# Altium schematic sheet 0_Overview.SchDoc
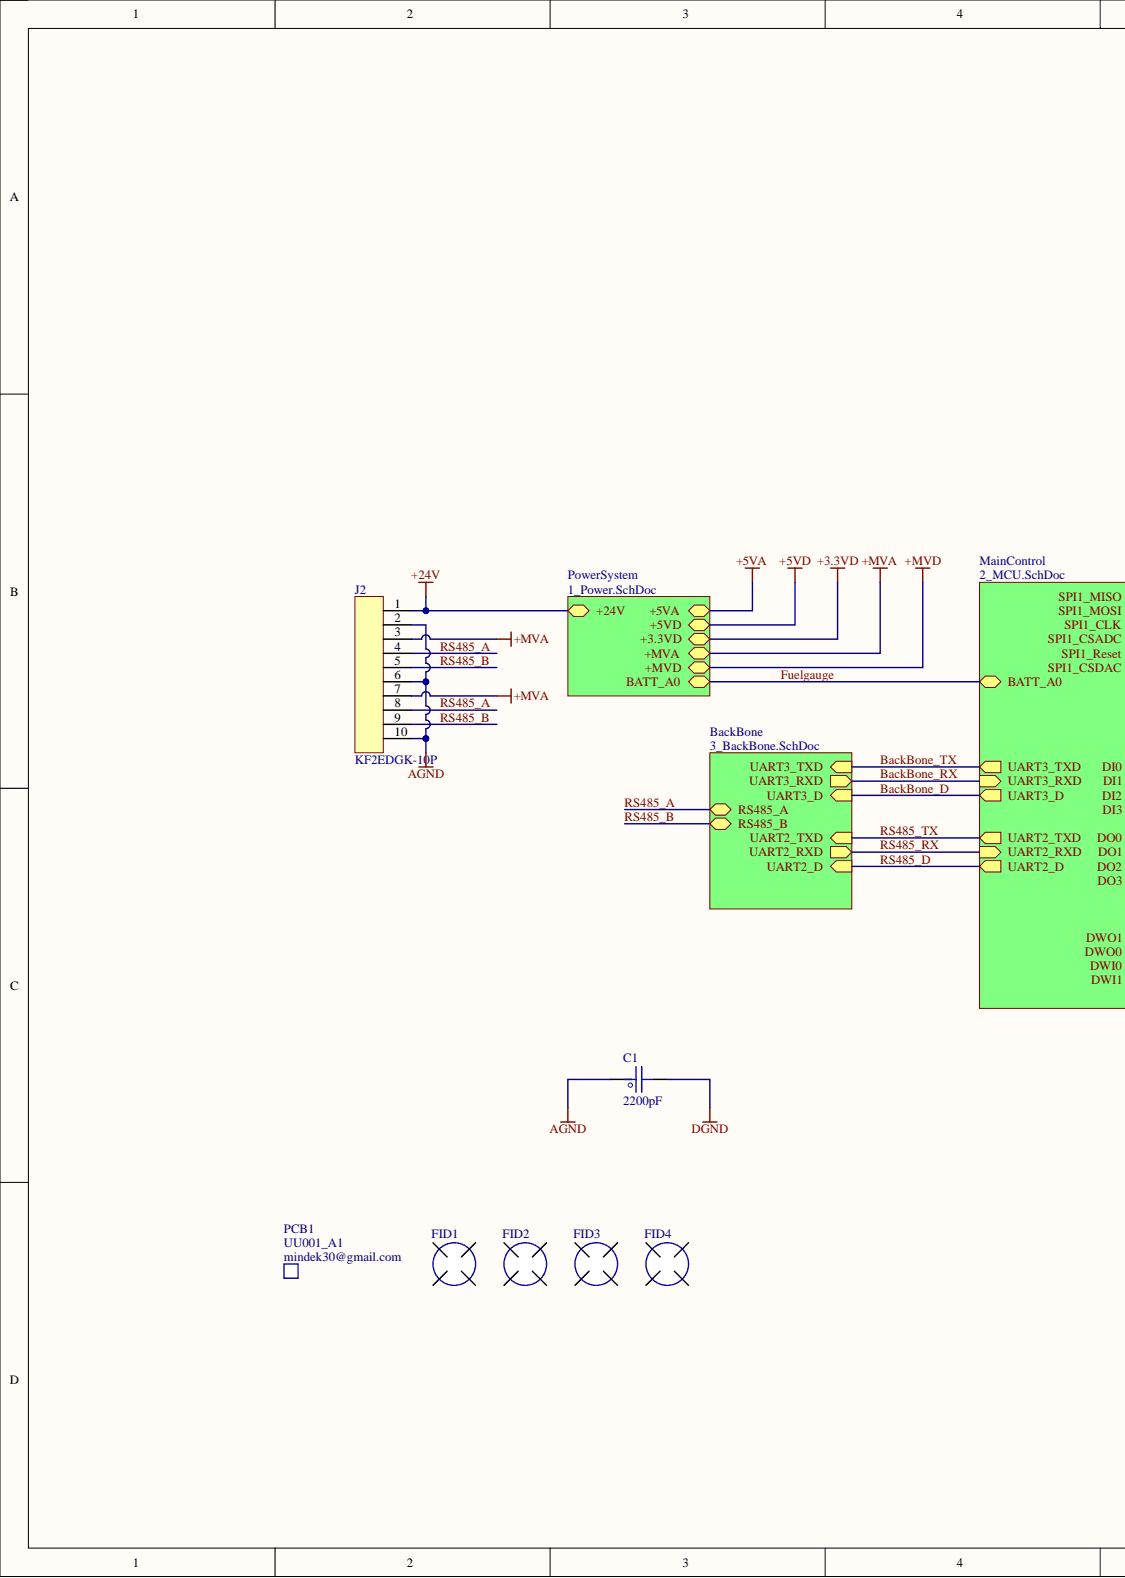
[diagram p0: 0_Overview.SchDoc, left]
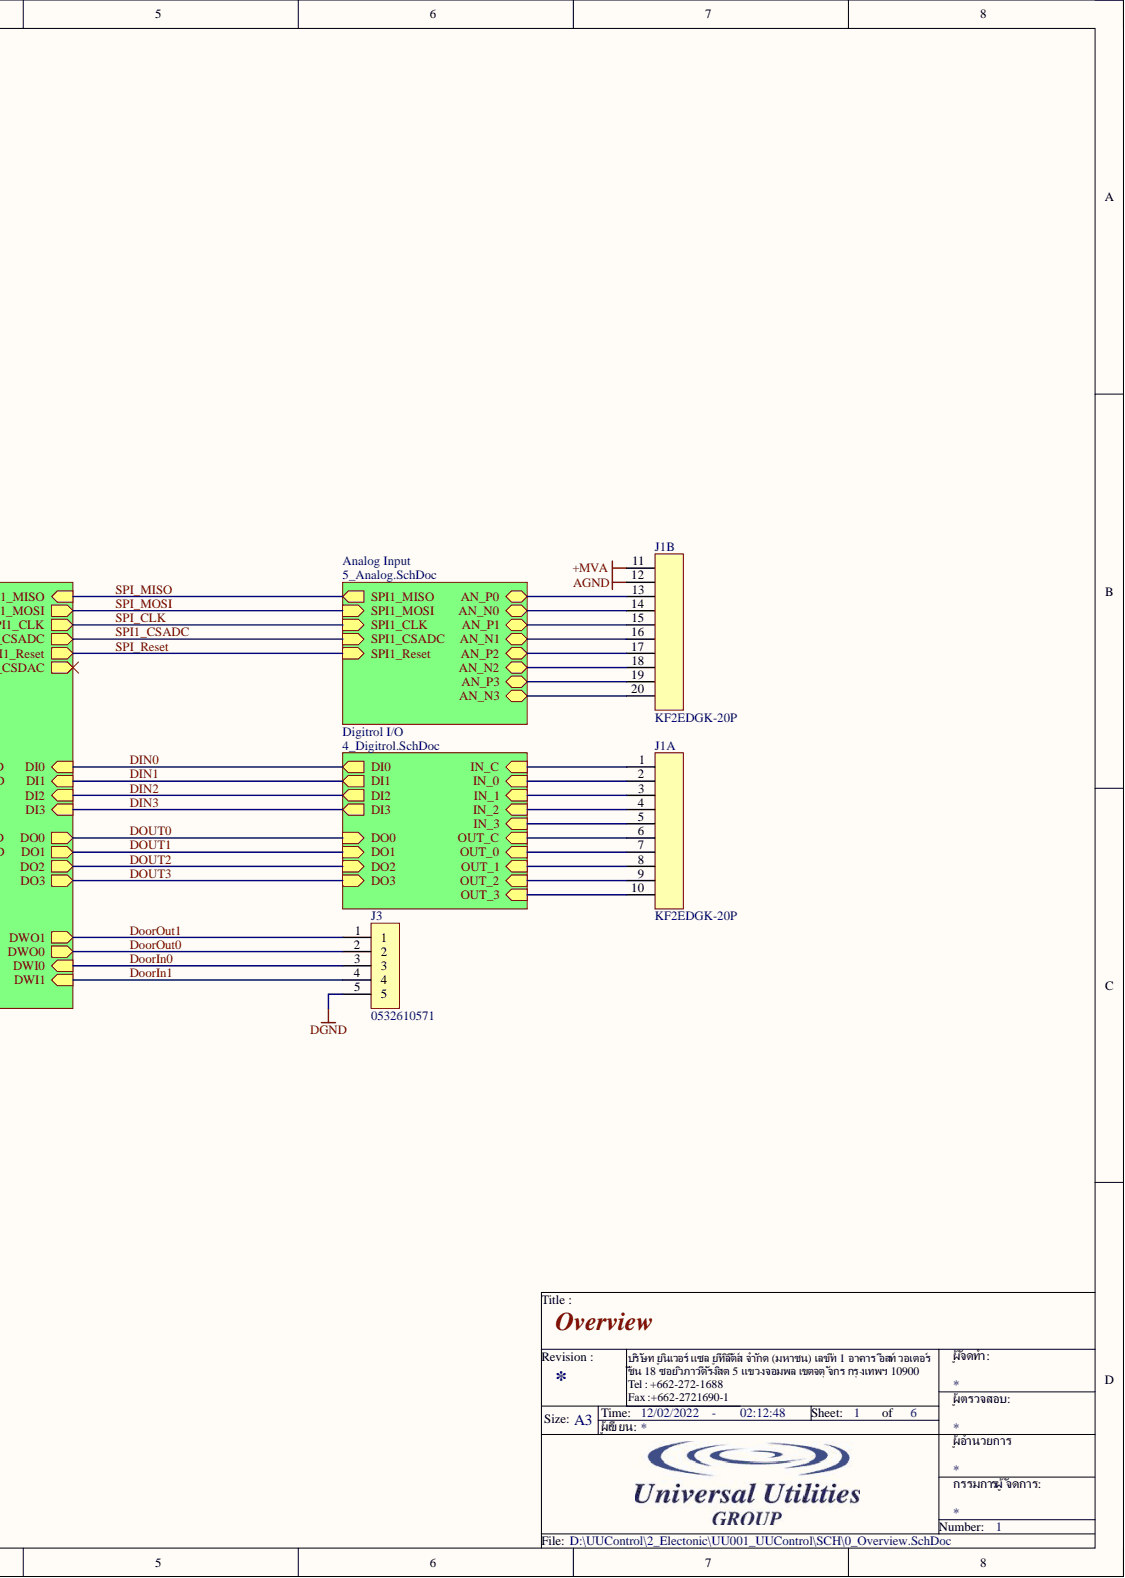
[diagram p1: 0_Overview.SchDoc, right]

## Parts
C1  2200pF  [C_1206]
FID1  FIDUCIAL  [FID]  (value not drawn)  (no BOM)
FID2  FIDUCIAL  [FID]  (value not drawn)  (no BOM)
FID3  FIDUCIAL  [FID]  (value not drawn)  (no BOM)
FID4  FIDUCIAL  [FID]  (value not drawn)  (no BOM)
J1  KF2EDGK-20P  [CON_20P_Terminal]  (parts A,B)
J2  KF2EDGK-10P  [CON_10P_Terminal]
J3  0532610571  [MOLEX_532610571]
PCB1  UU001_A1  Manufacturer: mindek30@gmail.com  (mechanical)

## Nets
+24V: J2.1
+MVA: J1.11 J2.3 J2.7
AGND: C1.1 J1.12 J2.2 J2.6 J2.10
DGND: C1.2 J3.5
DoorIn0: J3.3
DoorIn1: J3.4
DoorOut0: J3.2
DoorOut1: J3.1
RS485_A: J2.4 J2.8
RS485_B: J2.5 J2.9
NetJ1_1: J1.1
NetJ1_2: J1.2
NetJ1_3: J1.3
NetJ1_4: J1.4
NetJ1_5: J1.5
NetJ1_6: J1.6
NetJ1_7: J1.7
NetJ1_8: J1.8
NetJ1_9: J1.9
NetJ1_10: J1.10
NetJ1_13: J1.13
NetJ1_14: J1.14
NetJ1_15: J1.15
NetJ1_16: J1.16
NetJ1_17: J1.17
NetJ1_18: J1.18
NetJ1_19: J1.19
NetJ1_20: J1.20
+3.3VD:
+5VA:
+5VD:
+MVD:
BackBone_D:
BackBone_RX:
BackBone_TX:
DIN0:
DIN1:
DIN2:
DIN3:
DOUT0:
DOUT1:
DOUT2:
DOUT3:
Fuelgauge:
RS485_D:
RS485_RX:
RS485_TX:
SPI1_CSADC:
SPI1_CSDAC:
SPI_CLK:
SPI_MISO:
SPI_MOSI:
SPI_Reset:

## Library data of the parts
C1 = C_Capacitor: CAP CER 2200PF 1KV X7R 1206; Manufacturer: AVX Corporation; PartNumber: 1206AC222KAT1A; Component Kind: Standard; PackageReference: 1206; PartID: 478-2953-1-ND; Value: 2200pF; Value2: 1kV; Library Name: UUSymbol.SchLib
FID1-FID4 = FID_FIDUCIAL: Manufacturer: mindek30@gmail.com; PartNumber: UU001_A1; Value: Non SE; Description: Fiducial; Library Name: UUSymbol.SchLib; PackageReference: Non SE; PartID: A1; Value2: Non SE; Value3: Non SE
J1 = CON_20P_Terminal: TERM BLOCK HDR 20POS 90DEG 3.5MM; Value3: 1966172; Component Kind: Standard; PartID: KF2EDGK-20P; PartNumber: KF2EDGK-20P; Value: KF2EDGK-20P; Library Name: UUSymbol.SchLib
J2 = CON_10P_Terminal: TERM BLOCK HDR 10POS 90DEG 3.5MM; Value3: 1966172; Component Kind: Standard; PartID: KF2EDGK-10P; PartNumber: KF2EDGK-10P; Value: KF2EDGK-10P; Library Name: UUSymbol.SchLib
J3 = CON_5P_532610571: CONN HEADER SMD R/A 5POS 1.25MM; Manufacturer: Molex; Value2: AFC12-S05DCC-00; Component Kind: Standard; PackageReference: 5P; PartID: WM7623CT-ND; PartNumber: 0532610571; Value: 0532610571; Library Name: UUSymbol.SchLib
PCB1 = PCB_Bare: BARE PCB; Library Name: UUSymbol.SchLib; PackageReference: Non SE; PartID: A1; PartNumber: UU001_A1; Value: UU001_A1; Value3: UU001_A1
